# Altium schematic sheet led_driver.SchDoc(led_driver)
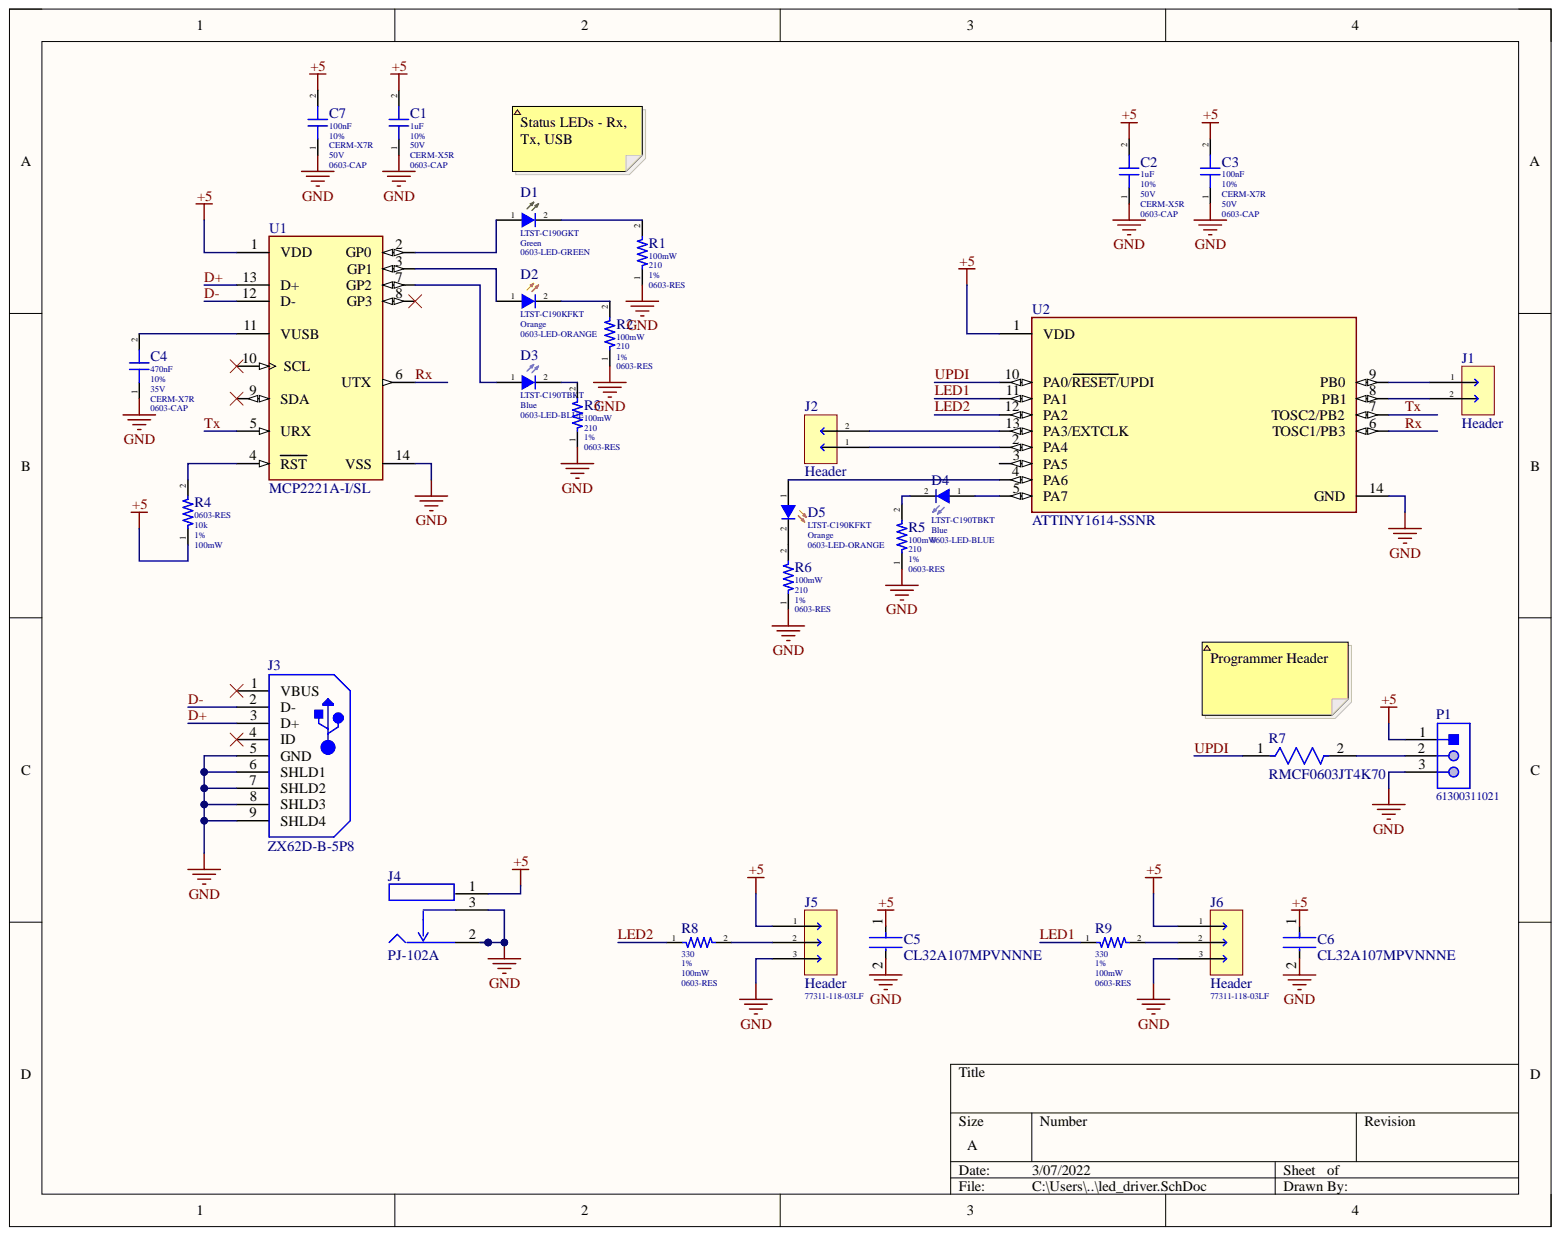
[diagram p0: led_driver.SchDoc]

## Parts
C1  Capacitance: 1uF  Dielectric: CERM-X5R  Tolerance: 10%  Voltage Rating: 50V  [0603-CAP]  (hidden comment: CAP SAMSUNG CL10A105KB8NNNC)
C2  Capacitance: 1uF  Dielectric: CERM-X5R  Tolerance: 10%  Voltage Rating: 50V  [0603-CAP]  (hidden comment: CAP SAMSUNG CL10A105KB8NNNC)
C3  Capacitance: 100nF  Dielectric: CERM-X7R  Tolerance: 10%  Voltage: 50V  [0603-CAP]  (hidden comment: CAP TDK CGA3E2X7R1H104K080AA)
C4  Capacitance: 470nF  Dielectric: CERM-X7R  Tolerance: 10%  Voltage Rating: 35V  [0603-CAP]  (hidden comment: CAP TDK CGA3E1X7R1V474K080AC)
C5  CL32A107MPVNNNE  [FP-CL32-IPC_C]
C6  CL32A107MPVNNNE  [FP-CL32-IPC_C]
C7  Capacitance: 100nF  Dielectric: CERM-X7R  Tolerance: 10%  Voltage: 50V  [0603-CAP]  (hidden comment: CAP TDK CGA3E2X7R1H104K080AA)
D1  Color: Green  Manufacturer Part Number: LTST-C190GKT  [0603-LED-GREEN]  (hidden comment: LED LITE-ON LTST-C190GKT)
D2  Color: Orange  Manufacturer Part Number: LTST-C190KFKT  [0603-LED-ORANGE]  (hidden comment: LED LITE-ON LTST-C190KFKT)
D3  Color: Blue  Manufacturer Part Number: LTST-C190TBKT  [0603-LED-BLUE]  (hidden comment: LED LITE-ON LTST-C190TBKT)
D4  Color: Blue  Manufacturer Part Number: LTST-C190TBKT  [0603-LED-BLUE]  (hidden comment: LED LITE-ON LTST-C190TBKT)
D5  Color: Orange  Manufacturer Part Number: LTST-C190KFKT  [0603-LED-ORANGE]  (hidden comment: LED LITE-ON LTST-C190KFKT)
J1  Header  [HEADER 2POS 1ROW 2.54MM W/O BODY]
J2  Header  [HEADER 2POS 1ROW 2.54MM W/O BODY]
J3  ZX62D-B-5P8  [HIRO-ZX62D-B-5P8_V]
J4  PJ-102A  [FP-PJ-102A-MFG]
J5  Header  Manufacturer Part Number: 77311-118-03LF  [HEADER 3POS 1ROW 2.54MM]
J6  Header  Manufacturer Part Number: 77311-118-03LF  [HEADER 3POS 1ROW 2.54MM]
P1  61300311021  [61300311021]
R1  Power Rating: 100mW  Tolerance: 1%  [0603-RES]  (hidden comment: RES KOA RK73H1JTTD2100F)
R2  Power Rating: 100mW  Tolerance: 1%  [0603-RES]  (hidden comment: RES KOA RK73H1JTTD2100F)
R3  Power Rating: 100mW  Tolerance: 1%  [0603-RES]  (hidden comment: RES KOA RK73H1JTTD2100F)
R4  Power: 100mW  Resistance: 10k  Tolerance: 1%  [0603-RES]  (hidden comment: RES BOURNS CR0603AFX-1002EAS)
R5  Power Rating: 100mW  Tolerance: 1%  [0603-RES]  (hidden comment: RES KOA RK73H1JTTD2100F)
R6  Power Rating: 100mW  Tolerance: 1%  [0603-RES]  (hidden comment: RES KOA RK73H1JTTD2100F)
R7  RMCF0603JT4K70  [FP-RMCF0603-MFG]
R8  Power Rating: 100mW  Tolerance: 1%  [0603-RES]  (hidden comment: RES KOA RK73H1JTTD3300F)
R9  Power Rating: 100mW  Tolerance: 1%  [0603-RES]  (hidden comment: RES KOA RK73H1JTTD3300F)
U1  MCP2221A-I/SL  [FP-C04-065-SL-MFG]
U2  ATTINY1614-SSNR  [FP-C04-065-D3X-MFG]

## Nets
+5: C1.2 C2.2 C3.2 C5.1 C6.1 C7.2 J4.1 J5.1 J6.1 P1.1 R4.1 U1.1(VDD) U2.1(VDD)
GND: C1.1 C2.1 C3.1 C4.1 C5.2 C6.2 C7.1 J3.5(GND) J3.6(SHLD1) J3.7(SHLD2) J3.8(SHLD3) J3.9(SHLD4) J4.2 J4.3 J5.3 J6.3 P1.3 R1.1 R2.1 R3.1 R5.1 R6.1 U1.14(VSS) U2.14(GND)
D+: J3.3(D+) U1.13(D+)
D-: J3.2(D-) U1.12(D-)
LED1: R9.1 U2.11(PA1)
LED2: R8.1 U2.12(PA2)
Rx: U1.6(UTX) U2.6(TOSC1/PB3)
Tx: U1.5(URX) U2.7(TOSC2/PB2)
UPDI: R7.1 U2.10(PA0/RESET/UPDI)
NetC4_2: C4.2 U1.11(VUSB)
NetD1_1: D1.1 U1.2(GP0)
NetD1_2: D1.2 R1.2
NetD2_1: D2.1 U1.3(GP1)
NetD2_2: D2.2 R2.2
NetD3_1: D3.1 U1.7(GP2)
NetD3_2: D3.2 R3.2
NetD4_1: D4.1 U2.5(PA7)
NetD4_2: D4.2 R5.2
NetD5_1: D5.1 U2.4(PA6)
NetD5_2: D5.2 R6.2
NetJ1_1: J1.1 U2.9(PB0)
NetJ1_2: J1.2 U2.8(PB1)
NetJ2_1: J2.1 U2.2(PA4)
NetJ2_2: J2.2 U2.13(PA3/EXTCLK)
NetJ5_2: J5.2 R8.2
NetJ6_2: J6.2 R9.2
NetP1_2: P1.2 R7.2
NetR4_2: R4.2 U1.4(RST)

Not connected: J3 1(VBUS) 4(ID); U1 8(GP3) 9(SDA) 10(SCL); U2 3(PA5)

## Library data of the parts
C1 C2 = CAP SAMSUNG CL10A105KB8NNNC: CAP CER 1UF 50V X5R 0603; Case Code (Imperial): 0603; Case Code (Metric): 1608; Case/Package: 0603; Component Kind: Standard; ComponentLink1Description: https://www.verical.com/server-webapp/html/getDatasheet?partID=753736&src-supplier=Verical; ComponentLink1URL: https://www.verical.com/server-webapp/html/getDatasheet?partID=753736&src-supplier=Verical; Contact Plating: Tin; CurrentFootprint: 0603-CAP; Depth: 0.8mm; Length: 1.6mm; Manufacturer: Samsung; Manufacturer Part Number: CL10A105KB8NNNC; Max Operating Temperature: 85°C; Min Operating Temperature: -55°C; Mount: Surface Mount; Package Quantity: 1; Packaging: Tape and Reel; Pricing 1: 1=0.17, 10=0.121, 100=0.0568, 500=0.0398, 1000=0.033 (USD); Radiation Hardening: No; Stock 1: 2147093; Supplier 1: Digi-Key; Supplier Part Number 1: 1276-1860-1-ND; Termination: Wraparound; Thickness: 0.035inch; Width: 0.031inch; Library Name: MHYPE Devices.IntLib
C3 C7 = CAP TDK CGA3E2X7R1H104K080AA: CAP CER 0.1UF 50V X7R 0603; Case Code (Imperial): 0603; Case Code (Metric): 1608; Case/Package: 0603; Component Kind: Standard; ComponentLink1Description: http://www.farnell.com/datasheets/1796654.pdf?src-supplier=Mouser; ComponentLink1URL: http://www.farnell.com/datasheets/1796654.pdf?src-supplier=Mouser; ComponentLink2Description: http://product.tdk.com/capacitor/mlcc/en/documents/mlccspec_automotive_general_midvoltage_en.pdf?src-supplier=Future+Electronics; ComponentLink2URL: http://product.tdk.com/capacitor/mlcc/en/documents/mlccspec_automotive_general_midvoltage_en.pdf?src-supplier=Future+Electronics; ComponentLink3Description: http://www.farnell.com/datasheets/1735392.pdf?src-supplier=Element14; ComponentLink3URL: http://www.farnell.com/datasheets/1735392.pdf?src-supplier=Element14; ComponentLink4Description: https://www.verical.com/server-webapp/html/getDatasheet?partID=715867&src-supplier=Verical; ComponentLink4URL: https://www.verical.com/server-webapp/html/getDatasheet?partID=715867&src-supplier=Verical; ComponentLink5Description: http://www.farnell.com/datasheets/1796654.pdf?src-supplier=Newark; ComponentLink5URL: http://www.farnell.com/datasheets/1796654.pdf?src-supplier=Newark; Composition: Ceramic; CurrentFootprint: 0603-CAP; Depth: 0.8mm; Height: 0.8mm; Lead Free: Lead Free; Length: 1.6mm; Manufacturer: TDK; Manufacturer Part Number: CGA3E2X7R1H104K080AA; Max Operating Temperature: 125°C; Min Operating Temperature: -55°C; Mount: Surface Mount; Package Quantity: 1; Packaging: Tape and Reel; Pricing 1: 1=0.1, 10=0.075, 100=0.0335, 500=0.0239, 1000=0.0188 (USD); Radiation Hardening: No; Ratings: AEC-Q200; REACH SVHC: No SVHC; Stock 1: 11391991; Supplier 1: Digi-Key; Supplier Part Number 1: 445-5666-1-ND; Termination: SMD/SMT; Thickness: 0.035inch; Voltage Rating: 50V; Voltage Rating (DC): 50V; Weight: 7.1E-05oz; Width: 0.8mm; Library Name: MHYPE Devices.IntLib
C4 = CAP TDK CGA3E1X7R1V474K080AC: CAP CER 0.47UF 35V X7R 0603; Case Code (Imperial): 0603; Case Code (Metric): 1608; Case/Package: 0603; Component Kind: Standard; ComponentLink1Description: http://product.tdk.com/capacitor/mlcc/detailed_information.php?lang=en&amp;ref=jp&amp;part_no=CGA3E1X7R1V474K080AC&src-supplier=Mouser; ComponentLink1URL: http://product.tdk.com/capacitor/mlcc/detailed_information.php?lang=en&amp;ref=jp&amp;part_no=CGA3E1X7R1V474K080AC&src-supplier=Mouser; ComponentLink2Description: http://www.farnell.com/datasheets/1796654.pdf?src-supplier=Element14; ComponentLink2URL: http://www.farnell.com/datasheets/1796654.pdf?src-supplier=Element14; Composition: Ceramic; CurrentFootprint: 0603-CAP; Depth: 0.8mm; Height: 0.8mm; Length: 1.6mm; Manufacturer: TDK; Manufacturer Part Number: CGA3E1X7R1V474K080AC; Max Operating Temperature: 125°C; Min Operating Temperature: -55°C; Mount: Surface Mount; Package Quantity: 1; Packaging: Tape and Reel; Pricing 1: 1=0.26, 10=0.181, 100=0.1026, 500=0.0725, 1000=0.0634 (USD); Ratings: AEC-Q200; REACH SVHC: No SVHC; Stock 1: 14632; Supplier 1: Digi-Key; Supplier Part Number 1: 445-6928-1-ND; Termination: SMD/SMT; Thickness: 0.035inch; Voltage Rating (DC): 35V; Weight: 7.1E-05oz; Width: 0.8mm; Library Name: MHYPE Devices.IntLib
C5 C6 = CMP-13271-001285-1: Cap Ceramic 100uF 10V X5R ±20% SMD 1210 +85°C Embossed T/R; Capacitance: 100uF; Case Code (Imperial): 1210; Case Code (Metric): 3225; Case/Package: 1210; Component Kind: Standard; Contact Plating: Tin; Depth: 2.5mm; Dielectric: X5R; Height: 2.5mm; Lead Free: Lead Free; Length: 3.2mm; Library Name: Altium Content Vault; Material: Ceramic; Max Operating Temperature: 85°C; Min Operating Temperature: -55°C; Mount: Surface Mount; Packaging: Tape and Reel; Radiation Hardening: No; Thickness: 0.11inch; Tolerance: 20%; Voltage: 10V; Voltage Rating: 10V; Voltage Rating (DC): 10V; Width: 0.098inch
D1 = LED LITE-ON LTST-C190GKT: LED GREEN CLEAR CHIP SMD; Manufacturer: Vishay Lite-On; Dominant Wavelength: 569nm; Forward Current: 30mA; Forward Voltage: 2.1V; Lens Color: Colorless; Lens Style: Clear,Diffused,Colorless,Rectangular; Luminous Intensity: 6mcd; Number of Elements: 1; Power Dissipation: 100mW; Reverse Voltage: 5V; Test Current: 10mA; Viewing Angle: 130°; Voltage: 2V; Wavelength: 565nm; Case Code (Imperial): 0603; Case Code (Metric): 1608; Case/Package: 0603; Component Kind: Standard; ComponentLink1Description: http://uk.rs-online.com/webdocs/0dc0/0900766b80dc02a6.pdf?src-supplier=RSComponents; ComponentLink1URL: http://uk.rs-online.com/webdocs/0dc0/0900766b80dc02a6.pdf?src-supplier=RSComponents; ComponentLink2Description: https://media.digikey.com/pdf/Data%20Sheets/Lite-On%20PDFs/LTST-C190GKT.pdf?src-supplier=Digi-Key; ComponentLink2URL: https://media.digikey.com/pdf/Data%20Sheets/Lite-On%20PDFs/LTST-C190GKT.pdf?src-supplier=Digi-Key; ComponentLink3Description: http://www1.futureelectronics.com/doc/LITE%20ON/LITE-ON%20LTST-C190GKT.pdf?src-supplier=Future+Electronics; ComponentLink3URL: http://www1.futureelectronics.com/doc/LITE%20ON/LITE-ON%20LTST-C190GKT.pdf?src-supplier=Future+Electronics; ComponentLink4Description: https://media.digikey.com/pdf/Data%20Sheets/Lite-On%20PDFs/LTST-C190GKT.pdf?src-supplier=Mouser; ComponentLink4URL: https://media.digikey.com/pdf/Data%20Sheets/Lite-On%20PDFs/LTST-C190GKT.pdf?src-supplier=Mouser; CurrentFootprint: 0603-LED-GREEN; Depth: 0.8mm; Height: 0.8mm; Illumination Color: Green; Lead Free: Lead Free; Length: 1.6mm; Lens Transparency: Clear; Max Operating Temperature: 85°C; Min Operating Temperature: -55°C; Mount: Surface Mount; Number of LEDs: 1; Number of Pins: 2; Package Quantity: 1; Packaging: Tape and Reel; Power Rating: 100mW; Pricing 1: 1=0.26, 10=0.188, 100=0.0888, 500=0.0592, 1000=0.0464 (USD); Radiation Hardening: No; Stock 1: 773896; Supplier 1: Digi-Key; Supplier Part Number 1: 160-1183-1-ND; Width: 0.8mm; Library Name: MHYPE Devices.IntLib
D2 D5 = LED LITE-ON LTST-C190KFKT: LED ORANGE CLEAR CHIP SMD; Manufacturer: Vishay Lite-On; Dominant Wavelength: 605nm; Forward Current: 30mA; Forward Voltage: 2V; Lens Color: Colorless; Lens Style: Diffused,Colorless,Clear,Rectangular; Luminous Intensity: 90mcd; Number of Elements: 1; Power Dissipation: 75mW; Reverse Voltage: 5V; Test Current: 20mA; Viewing Angle: 130°; Wavelength: 611nm; Case Code (Imperial): 0603; Case Code (Metric): 1608; Case/Package: 0603; Component Kind: Standard; ComponentLink1Description: http://www.mouser.com/ds/2/239/LTST-C190KFKT-1141312.pdf?src-supplier=Mouser; ComponentLink1URL: http://www.mouser.com/ds/2/239/LTST-C190KFKT-1141312.pdf?src-supplier=Mouser; ComponentLink2Description: http://www1.futureelectronics.com/doc/LITE%20ON/LITE-ON%20LTST-C190KFKT.pdf?src-supplier=Future+Electronics; ComponentLink2URL: http://www1.futureelectronics.com/doc/LITE%20ON/LITE-ON%20LTST-C190KFKT.pdf?src-supplier=Future+Electronics; ComponentLink3Description: http://optoelectronics.liteon.com/upload/download/DS-22-99-0186/LTST-C190KFKT.PDF?src-supplier=Digi-Key; ComponentLink3URL: http://optoelectronics.liteon.com/upload/download/DS-22-99-0186/LTST-C190KFKT.PDF?src-supplier=Digi-Key; ComponentLink4Description: https://www.verical.com/server-webapp/html/getDatasheet?partID=309341&src-supplier=Verical; ComponentLink4URL: https://www.verical.com/server-webapp/html/getDatasheet?partID=309341&src-supplier=Verical; CurrentFootprint: 0603-LED-ORANGE; Depth: 1.6mm; Height: 0.8mm; Illumination Color: Orange; Lead Free: Lead Free; Length: 3.2mm; Lens Transparency: Clear; Max Operating Temperature: 85°C; Min Operating Temperature: -55°C; Mount: Surface Mount; Number of LEDs: 1; Number of Pins: 2; Package Quantity: 1; Packaging: Tape and Reel; Power Rating: 75mW; Pricing 1: 1=0.24, 10=0.175, 100=0.0827, 500=0.0551, 1000=0.0432 (USD); Radiation Hardening: No; Stock 1: 52285; Supplier 1: Digi-Key; Supplier Part Number 1: 160-1434-1-ND; Width: 0.8mm; Library Name: MHYPE Devices.IntLib
D3 D4 = LED LITE-ON LTST-C190TBKT: LED BLUE CLEAR CHIP SMD; Manufacturer: Vishay Lite-On; Dominant Wavelength: 475nm; Forward Current: 20mA; Forward Voltage: 3.8V; Lens Color: Colorless; Lens Style: Diffused,Colorless,Clear,Rectangular; Luminous Intensity: 180mcd; Number of Elements: 1; Power Dissipation: 76mW; Reverse Voltage: 5V; Viewing Angle: 130°; Wavelength: 468nm; Case Code (Imperial): 0603; Case Code (Metric): 1608; Case/Package: 0603; Component Kind: Standard; ComponentLink1Description: http://www1.futureelectronics.com/doc/LITE%20ON/LITE-ON%20LTST-C190TBKT.pdf?src-supplier=Future+Electronics; ComponentLink1URL: http://www1.futureelectronics.com/doc/LITE%20ON/LITE-ON%20LTST-C190TBKT.pdf?src-supplier=Future+Electronics; ComponentLink2Description: https://www.verical.com/server-webapp/html/getDatasheet?partID=131310&src-supplier=Verical; ComponentLink2URL: https://www.verical.com/server-webapp/html/getDatasheet?partID=131310&src-supplier=Verical; ComponentLink3Description: http://optoelectronics.liteon.com/upload/download/DS-22-99-0224/LTST-C190TBKT.PDF?src-supplier=Digi-Key; ComponentLink3URL: http://optoelectronics.liteon.com/upload/download/DS-22-99-0224/LTST-C190TBKT.PDF?src-supplier=Digi-Key; ComponentLink4Description: http://www.mouser.com/ds/2/239/Lite-On-LTST-C190TBKT-187381.pdf?src-supplier=Mouser; ComponentLink4URL: http://www.mouser.com/ds/2/239/Lite-On-LTST-C190TBKT-187381.pdf?src-supplier=Mouser; ComponentLink5Description: http://datasheet.iiic.cc/lite-on/LTST-C190TBKT.pdf?src-supplier=IIIC; ComponentLink5URL: http://datasheet.iiic.cc/lite-on/LTST-C190TBKT.pdf?src-supplier=IIIC; CurrentFootprint: 0603-LED-BLUE; Depth: 0.8mm; Height: 0.8mm; Illumination Color: Blue; Lead Free: Lead Free; Length: 1.6mm; Lens Transparency: Clear; Max Operating Temperature: 80°C; Min Operating Temperature: -20°C; Mount: Surface Mount; Number of LEDs: 1; Number of Pins: 2; Package Quantity: 1; Packaging: Tape and Reel; Pricing 1: 1=0.52, 10=0.374, 100=0.1663, 500=0.1164, 1000=0.0956 (USD); Radiation Hardening: No; Stock 1: 176531; Supplier 1: Digi-Key; Supplier Part Number 1: 160-1646-1-ND; Test Current: 20mA; Width: 0.8mm; Library Name: MHYPE Devices.IntLib
J1 J2 = HEADER 2POS: CONN HEADER VERT 2POS 2.54MM; Component Kind: Standard; Library Name: MHYPE Connectors.IntLib
J3 = CMP-0029-00002-1: Right Angle Receptacle Connector, Micro USB, 30 V(AC), -30 to 85 degC, 5-Pin SMD, RoHS, Tape and Reel; Capacitance: 1 uF; ESR: 7.5 Ohm; Tolerance: 10%; Voltage Rating: 35 V; Case Code (Imperial): 1206; Case Code (Metric): 3216; Case/Package: 1206; Component Kind: Standard; ComponentLink1Description: Manufacturer URL; ComponentLink1URL: https://www.hirose.com; ComponentLink2Description: Datasheet; ComponentLink2URL: https://www.hirose.com/product/en/download_file/key_name/ZX62D-B-5P8/category/Catalog/doc_file_id/31704/?file_category_id=5&item_id=02420034000&is_series=; ComponentLink3Description: Package Specification; ComponentLink3URL: https://www.hirose.com/product/en/download_file/key_name/ZX62D-B-5P8/category/Drawing%20(2D)/doc_file_id/36535/?file_category_id=6&item_id=02420034000&is_series=; DatasheetVersion: Aug-16; Height: 1.8 mm; Length: 3.2 mm; Library Name: Altium Content Vault; Max Operating Temperature: 125degC; Min Operating Temperature: -55degC; Mount: Surface Mount; Package Quantity: 2000; PackageDescription: USB Connector, 5 Position Surface Mount, Length 8 mm, Height 2.65 mm; PackageReference: ZX62D-B-5P8; Packaging: Tape and Reel; Pins: 2; Radiation Hardening: No; REACH SVHC: No SVHC; Resistance: 7.5 Ohm; RoHSCompliant: True; Voltage Rating (DC): 35 V; Weight: 0.000571 oz; Width: 1.6 mm
J4 = CMP-2000-06899-2: CONN PWR JACK 2X5.5MM SOLDER; Manufacturer: CUI; Conn Gender: Male; Conn Orientation: Right Angle; Current Rating: 2.5A; Industry Recognized Mating Diameter: 2.10mm ID (0.083"), 5.50mm OD (0.217"); Number Of Contacts: 3; Number of Positions/Contacts: 2 Conductors, 3 Contacts; Voltage: 24V; Automotive: No; Category: Conn; Component Kind: Standard; ComponentLink2Description: Datasheet; ComponentLink2URL: http://www.cui.com/product/resource/pj-102a.pdf; Contact Material: Tin,Nickel; Device Class L1: Connectors; Device Class L2: Power Connectors; Device Class L3: unset; Digikey Description: CONN PWR JACK 2X5.5MM SOLDER; Height: 11.2mm; Lead Free: Yes; Library Name: Altium Content Vault; Manufacturer Part Number: PJ-102A; Mouser Description: DC Power Connectors Power Jacks; Octopart: https://octopart.com/pj-102a-cui-286936; Package: PJ-102A; RoHS: TRUE; Temperature: -25°C to +85°C; Temperature Range High: +85°C; Temperature Range Low: -25°C; Voltage Rating: 24V
J5 J6 = HEADER AMPHENOL 77311-118-03LF: CONN HEADER VERT 3POS 2.54MM; Manufacturer: Amphenol FCI; Connector Type: Male Pin; Contact Post Length: 3.05mm; Current Rating: 3A; Gender: Male; Insulation Resistance: 5GR; Max Voltage Rating (AC): 1.5kV; Number of Contacts: 3; Color: Black; Component Kind: Standard; ComponentLink1Description: http://www.farnell.com/datasheets/52620.pdf?src-supplier=Element14; ComponentLink1URL: http://www.farnell.com/datasheets/52620.pdf?src-supplier=Element14; ComponentLink2Description: http://portal.fciconnect.com/Comergent/fci/drawing/77311.pdf?src-supplier=Avnet; ComponentLink2URL: http://portal.fciconnect.com/Comergent/fci/drawing/77311.pdf?src-supplier=Avnet; ComponentLink3Description: http://portal.fciconnect.com/Comergent//fci/drawing/77311.pdf?src-supplier=Digi-Key; ComponentLink3URL: http://portal.fciconnect.com/Comergent//fci/drawing/77311.pdf?src-supplier=Digi-Key; Contact Gender: Male; Contact Material: Bronze; Contact Mating Length: 0.23inch; Contact Plating: Gold,GXT; Depth: 2.4mm; Flammability Rating: UL94 V-0; Housing Color: Black; Housing Material: Thermoplastic; Lead Free: Lead Free; Length: 7.62mm; Material: Thermoplastic; Max Operating Temperature: 125°C; Min Operating Temperature: -65°C; Mount: Through Hole; Number of Positions: 3; Number of Rows: 1; Orientation: Straight; Package Quantity: 1000; Packaging: Bulk; Pitch: 2.54mm; Pricing 1: 1=0.21, 10=0.197, 100=0.1362, 500=0.1153, 1000=0.0943, 5000=0.0838, 10000=0.076 (USD); Radiation Hardening: No; REACH SVHC: No SVHC; Stock 1: 0; Supplier 1: Digi-Key; Supplier Part Number 1: 609-5680-ND; Termination: Solder; Voltage Rating: 1.5kV; Library Name: MHYPE Connectors.IntLib
P1 = CMP-1502-01061-1: THT Angled Pin Header WR-PHD, Pitch 2.54 mm, Single Row, 3 pins; Manufacturer: Wurth Elektronik; Contact Resistance: 20mOhm; Gender: Male; Pin Number: 3; Pitch: 2.54mm; Rated Current: 3A; Withstanding Voltage: 500VAC; Working Voltage: 250VAC; Component Kind: Standard; Datasheet URL: https://katalog.we-online.de/em/datasheet/6130xx11021.pdf; Library Name: Altium Content Vault; Manufacturer URL: http://www.we-online.de; Mounting Technology: THT; Operating Temperature: -40to105degC; Orientation: Angled; Package Reference: 61300311021; Packaging: Bag; Part Number: 61300311021; Row Number: Single; Publisher: WurthElektronik
R1-R3 R5 R6 = RES KOA RK73H1JTTD2100F: RES 210 OHM 1% 1/8W 0603; Manufacturer: KOA Speer; Resistance: 210; Temperature Coefficient: 100ppm/°C; Voltage Rating: 50V; Case Code (Imperial): 0603; Case Code (Metric): 1608; Case/Package: 0603; Component Kind: Standard; ComponentLink1Description: http://www.mouser.com/ds/2/219/RK73H-5715.pdf?src-supplier=Mouser; ComponentLink1URL: http://www.mouser.com/ds/2/219/RK73H-5715.pdf?src-supplier=Mouser; Current Footprint: 0603-RES; Height: 0.45mm; Lead Free: Lead Free; Length: 1.6mm; Manufacturer Part Number: RK73H1JTTD2100F; Max Operating Temperature: 155°C; Min Operating Temperature: -55°C; Package Quantity: 5000; Packaging: Tape and Reel; Pricing 1: 1=0.1, 10=0.023, 100=0.0095, 1000=0.0043, 2500=0.0037 (USD); Stock 1: 4900; Supplier 1: Digi-Key; Supplier Part Number 1: 2019-RK73H1JTTD2100FCT-ND; Termination: SMD/SMT; Voltage Rating (DC): 50V; Width: 0.8mm; Library Name: MHYPE Devices.IntLib
R4 = RES BOURNS CR0603AFX-1002EAS: RESA-AS 0603 10K 1% 100MW TC100; Component Kind: Standard; Current Footprint: 0603-RES; Manufacturer: Bourns; Manufacturer Part Number: CR0603AFX-1002EAS; Pricing 1: 1=0.1, 10=0.075, 100=0.0307, 1000=0.0138, 2500=0.012 (USD); Stock 1: 4756; Supplier 1: Digi-Key; Supplier Part Number 1: CR0603AFX-1002EASCT-ND; Library Name: MHYPE Devices.IntLib
R7 = CMP-26527-000065-1: RES 4.7K OHM 5% 1/10W 0603; Max Power Dissipation: 100mW; Resistance: 4.7kR; Temperature Coefficient: 200ppm/°C; Case Code (Imperial): 0603; Case Code (Metric): 1608; Case/Package: 0603; Component Kind: Standard; Construction: Rectangular; Contact Plating: Tin; Depth: 0.8mm; Features: Automotive AEC-Q200; Height: 0.022inch; Lead Free: Lead Free; Length: 1.55mm; Library Name: Altium Content Vault; Max Operating Temperature: 155°C; Military Standard: Not; Min Operating Temperature: -55°C; Mount: Surface Mount; Number of Terminations: 2; Packaging: Tape and Reel; Power Rating: 100mW; Radiation Hardening: No; Resistor Type: Thick Film; RoHS Compliant: Yes; Series: RMCF; Size Code: 0603; Tolerance: 5%; Width: 0.031inch
R8 R9 = RES KOA RK73H1JTTD3300F: RES 330 OHM 1% 1/8W 0603; Manufacturer: KOA Speer; Resistance: 330; Temperature Coefficient: 100ppm/°C; Voltage Rating: 50V; Case Code (Imperial): 0603; Case Code (Metric): 1608; Case/Package: 0603; Component Kind: Standard; ComponentLink1Description: http://www.farnell.com/datasheets/549017.pdf?src-supplier=Element14; ComponentLink1URL: http://www.farnell.com/datasheets/549017.pdf?src-supplier=Element14; ComponentLink2Description: http://www.mouser.com/ds/2/219/RK73H-5715.pdf?src-supplier=Mouser; ComponentLink2URL: http://www.mouser.com/ds/2/219/RK73H-5715.pdf?src-supplier=Mouser; ComponentLink3Description: https://www.distrelec.biz/Web/Downloads/_t/ds/rk73h-series_eng_jap_tds.pdf?mime=application%2Fpdf&src-supplier=Distrelec; ComponentLink3URL: https://www.distrelec.biz/Web/Downloads/_t/ds/rk73h-series_eng_jap_tds.pdf?mime=application%2Fpdf&src-supplier=Distrelec; Composition: Thick Film; Current Footprint: 0603-RES; Height: 0.45mm; Lead Free: Lead Free; Length: 1.6mm; Manufacturer Part Number: RK73H1JTTD3300F; Max Operating Temperature: 155°C; Min Operating Temperature: -55°C; Package Quantity: 5000; Packaging: Tape and Reel; Pricing 1: 1=0.1, 10=0.023, 100=0.0095, 1000=0.0043, 2500=0.0037 (USD); REACH SVHC: Unknown; Stock 1: 93540; Supplier 1: Digi-Key; Supplier Part Number 1: 2019-RK73H1JTTD3300FCT-ND; Termination: SMD/SMT; Width: 0.8mm; Library Name: MHYPE Devices.IntLib
U1 = CMP-06284-000011-1: IC USB TO I2C/UART 14SOIC; Manufacturer: Microchip; Automotive Grade: Grade 3; Data Rate: 12Mbits/s; Interface: I2C,UART,USB; Max Junction Temp: +150°C; Max Supply Voltage: 5.5V; Min Supply Voltage: 3V; Nominal Supply Current: 10-13mA; Standoff Height: 0.1mm; Usb Standard: USB 2.0; Ambient Temperature Range High: +85°C; Ambient Temperature Range Low: -40°C; Automotive: Yes; Category: IC; Component Kind: Standard; ComponentLink2Description: Datasheet; ComponentLink2URL: http://ww1.microchip.com/downloads/en/DeviceDoc/20005565B.pdf; Device Class L1: Integrated Circuits (ICs); Device Class L2: Interface ICs; Device Class L3: USB Interface ICs; Digikey Description: IC USB TO I2C/UART 14SOIC; Footprint Url: http://ww1.microchip.com/downloads/en/DeviceDoc/14L%20SOIC%203_90%20mm%20%28SL%29%20C04-00065d.pdf; Height: 1.75mm; Ipc Land Pattern Name: SOIC127P600X175-14; Lead Free: Yes; Library Name: Altium Content Vault; Manufacturer Part Number: MCP2221A-I/SL; Octopart: https://octopart.com/mcp2221a-i%2Fsl-microchip-71704986; Package: C04-065-SL; RoHS: TRUE; Temperature: -40°C to +85°C; Temperature Range High: +85°C; Temperature Range Low: -40°C
U2 = CMP-06276-000002-1: IC MCU 8BIT 16KB FLASH 14SOIC; Manufacturer: Microchip; Core Architecture: AVR; Data Bus Width: 8bits; Frequency: 20MHz; Interface: SPI,USART,2-Wire; Max Supply Voltage: 5.5V; Memory Size: 16KBytes; Min Supply Voltage: 1.8V; Nominal Supply Current: 0.005-10.2mA; Number Of A/D Converters: 2; Number Of Adc Channels: 10; Number Of Cores: 1; Number Of I/Os: 12; Number Of Pwm Channels: 4; Number Of Timers/Counters: 5; Ram Size: 2KBytes; Standoff Height: 0.1mm; Automotive: No; Category: IC; Component Kind: Standard; ComponentLink2Description: Datasheet; ComponentLink2URL: http://ww1.microchip.com/downloads/en/DeviceDoc/ATtiny1614-DataSheet-DS40001995B.pdf; Device Class L1: Integrated Circuits (ICs); Device Class L2: Embedded Processors and Controllers; Device Class L3: Microcontrollers; Digikey Description: IC MCU 8BIT 16KB FLASH 14SOIC; Height: 1.75mm; Ipc Land Pattern Name: SOIC127P600X175-14; Lead Free: Yes; Library Name: Altium Content Vault; Manufacturer Part Number: ATTINY1614-SSNR; Memory Type: FLASH; Number Of Spi Channels: 1; Number Of Uart Channels: 1; Octopart: https://octopart.com/attiny1614-ssnr-microchip-82955111; Package: C04-065-D3X; RoHS: TRUE; Temperature: -40°C to +105°C; Temperature Range High: +105°C; Temperature Range Low: -40°C
